# Altium schematic sheet Ethernet.SchDoc(Ethernet)
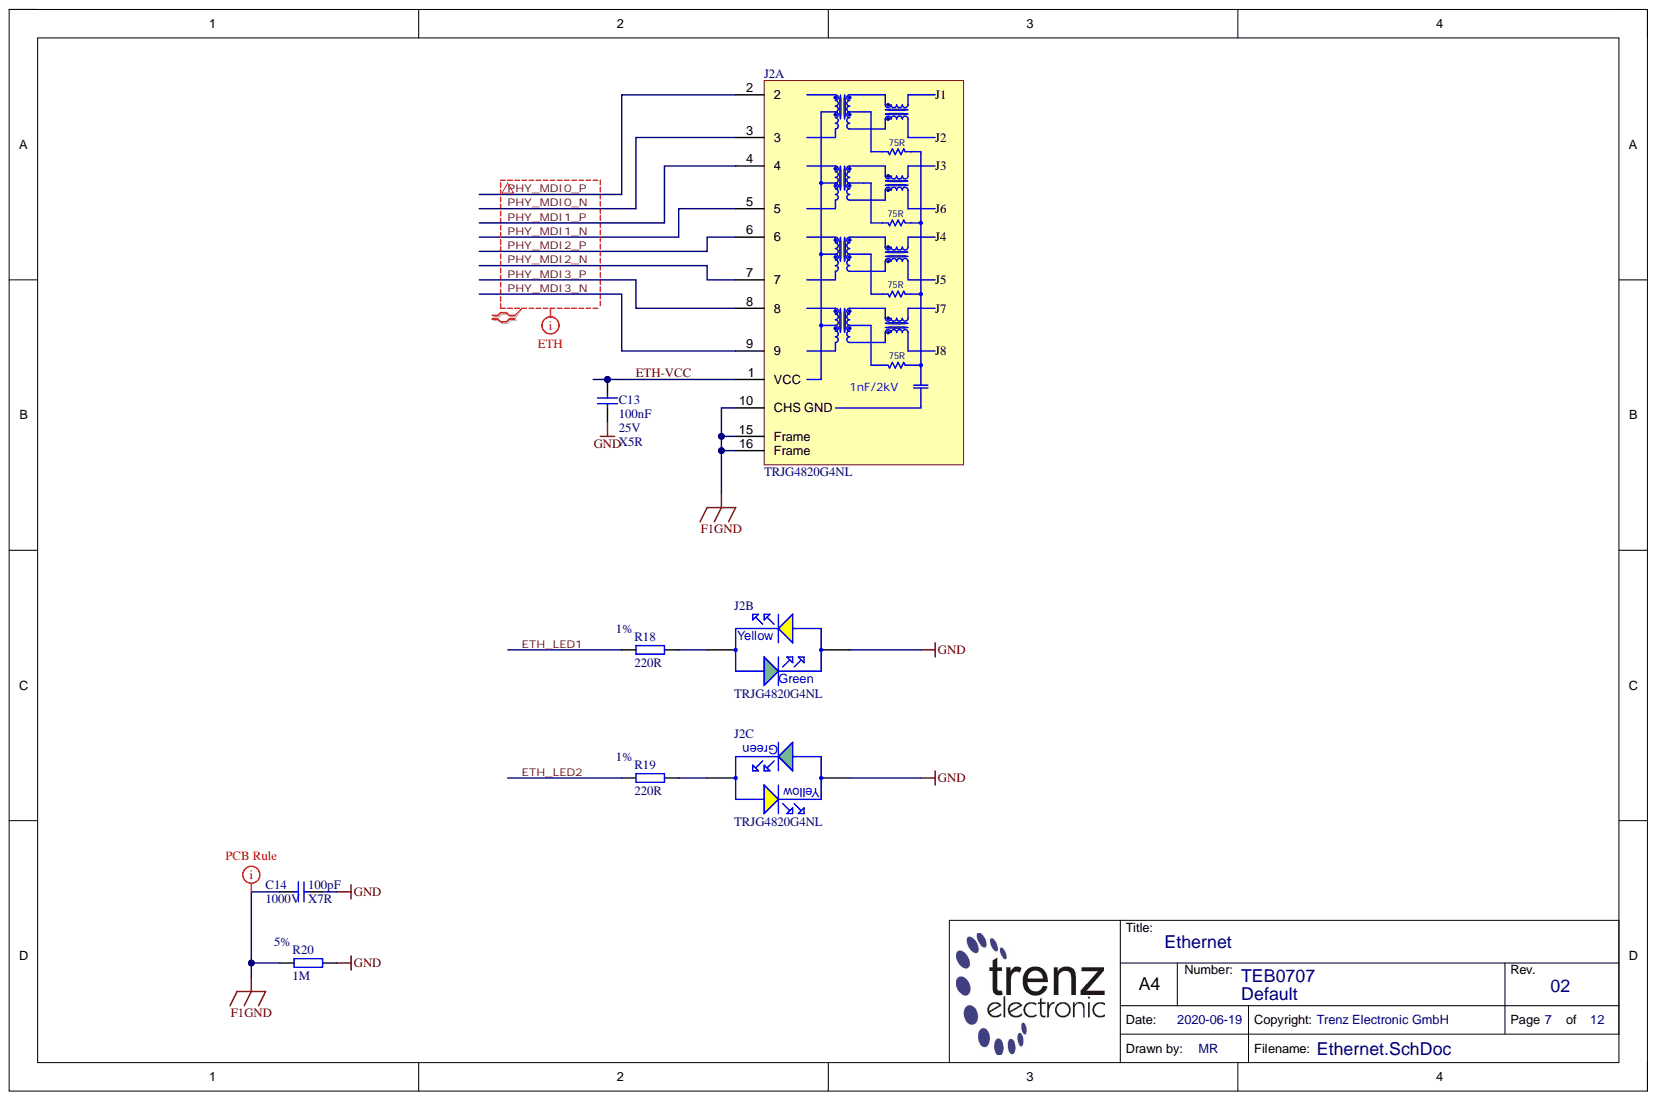
[diagram p0: Ethernet.SchDoc]

## Parts
C13  100nF  Technology: X5R  Voltage: 25V  [CAPC1005X55N]
C14  100pF  Technology: X7R  Voltage: 1000V  [CAPC3216X180N]
J2  TRJG4820G4NL  [MIC38013-5151T-LF3]  (parts A,B,C)
R18  220R  Tolerance: 1%  [RESC1005X40N]
R19  220R  Tolerance: 1%  [RESC1005X40N]
R20  1M  Tolerance: 5%  [RESC3216X65N]

## Nets
F1GND: C14.1 J2.10(CHS_GND) J2.15(Frame) J2.16(Frame) R20.1
GND: C13.1 C14.2 J2.12 J2.13 R20.2
ETH-VCC: C13.2 J2.1(VCC)
ETH_LED1: R18.1
ETH_LED2: R19.1
PHY_MDI0_N: J2.3
PHY_MDI0_P: J2.2
PHY_MDI1_N: J2.5
PHY_MDI1_P: J2.4
PHY_MDI2_N: J2.7
PHY_MDI2_P: J2.6
PHY_MDI3_N: J2.9
PHY_MDI3_P: J2.8
NetJ2_11: J2.11 R18.2
NetJ2_14: J2.14 R19.2

## Library data of the parts
C13 = 22472: KON 100nF 25V X5R ±10% SMD BF 1005 (0402); Database Table Name: C_1005_0402; Mixed: SMT; Preferred: Yes; Temperature-Range: -55/+85; Value: 100n; AN: 22472; Case: 1005 (0402); Component Kind: Standard; Library Name: TE.DbLib
C14 = 22679: KON 100pF 1000V X7R 10%  BF 3216 (1206); Database Table Name: C_3216_1206; Mixed: SMT; Preferred: Yes; Temperature-Range: -55/+125; Value: 100p; AN: 22679; Case: 3216 (1206); Component Kind: Standard; Library Name: TE.DbLib; Tolerance: 10%
J2 = 27357: LAN-Transformer 10/100/1000 BaseT; Database Table Name: CON_IO; Extra: TRJG4820G4NL, -40 to +85 °C,; Mixed: THT; Trenz-Stock: Yes; AN: 27357; Component Kind: Standard; H-ART: TRJG4820G4NL; Library Name: TE.DbLib; Technology: -40 to +85 °C; HelpURL: B:\ad\adlib\datasheet\TRJG4820G4NL
R18 R19 = 22767: WID SMD 220R 1% 0,063W  BF 1005 (0402); Database Table Name: R_1005_0402; Mixed: SMT; Preferred: Yes; Value: 220; AN: 22767; Case: 1005 (0402); Component Kind: Standard; Library Name: TE.DbLib; Technology: TK100
R20 = 22757: WID SMD 1M 5% 0,25W  BF 3216 (1206); Database Table Name: R_3216_1206; Mixed: SMT; Preferred: Yes; AN: 22757; Case: 3216 (1206); Component Kind: Standard; Library Name: TE.DbLib; Technology: TK100; Value: 1M
